# Altium schematic sheet G-USB.SchDoc(G-USB)
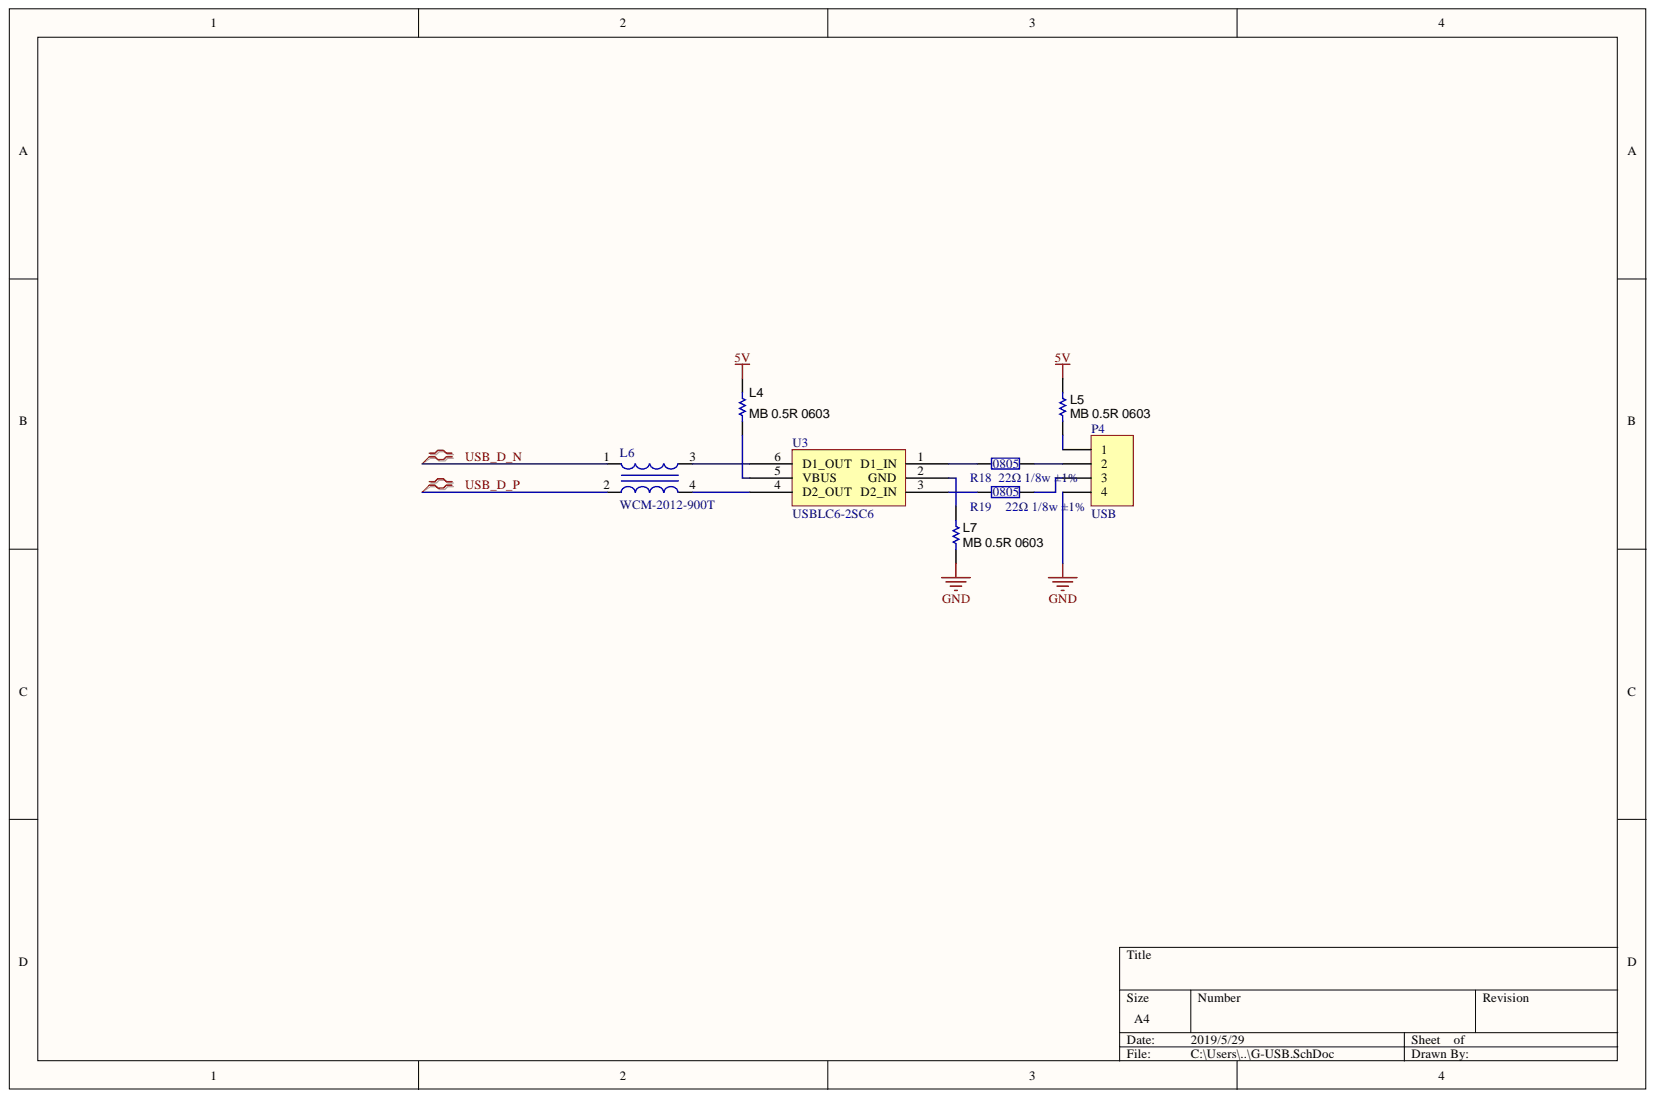
[diagram p0: G-USB.SchDoc]

## Parts
L4  MB 0.5R 0603  [R-0603]
L5  MB 0.5R 0603  [R-0603]
L6  WCM-2012-900T  [贴片共模电感-2520]
L7  MB 0.5R 0603  [R-0603]
P4  USB  [USB-B1]
R18  22Ω 1/8w ±1%  [R-0805]
R19  22Ω 1/8w ±1%  [R-0805]
U3  USBLC6-2SC6  [USBLC6]

## Nets
5V: L4.1 L5.1
GND: L7.2 P4.4
USB_D_N: L6.1
USB_D_P: L6.2
NetL4_2: L4.2 U3.5(VBUS)
NetL5_2: L5.2 P4.1
NetL6_3: L6.3 U3.6(D1_OUT)
NetL6_4: L6.4 U3.4(D2_OUT)
NetL7_1: L7.1 U3.2(GND)
NetP4_2: P4.2 R18.2
NetP4_3: P4.3 R19.2
NetR18_1: R18.1 U3.1(D1_IN)
NetR19_1: R19.1 U3.3(D2_IN)

## Library data of the parts
L4 L5 L7 = RC0603FR-074K99L: magnetic bead; Manufacturer: Yageo America; CompType: Resistor; EIA_Code: E96; Power_W: 0.1; Res_ohm: 4990; TempCo: 200 ppm/C; Tolerance_%: 1; U/M: EA; Value: 4.99k; Value1: 1%; Value2: 0.1W; Value_Code: 4k99; VDC_V: 150; Component Kind: Standard; ComponentLink1Description: Datasheet link; ComponentLink1URL: http://www.yageo.com/pdf/Pu-RC0603_51_PbFree_L_2.pdf; LatestRevisionDate: 2014-12-16; Library Name: Resistor_0603.IntLib; MountType: SMT; PackageReference: 0603; PartNumber: RC0603FR-074K99L; Published: 2008-06-26; RoHS: Y; Signal Integrity: Resistor; Simulation: Resistor; Supplier 1: Digi-Key; Supplier 2: Mouser; Supplier 3: Farnell; Supplier Part Number 1: 311-4.99KHRCT-ND; Supplier Part Number 2: 603-RC0603FR-074K99L; Supplier Part Number 3: 1799345; SymbolVersion: 2009-05-29; Technology: Thick Film; Publisher: Texas Instruments
L6 = INDUCTOR C: Component Kind: Standard; Library Name: DH-SchLib.SchLib
P4 = Header 4: Header, 4-Pin; Component Kind: Standard; LatestRevisionDate: 17-Jul-2002; LatestRevisionNote: Re-released for DXP Platform.; Library Name: Miscellaneous Connectors.IntLib; Signal Integrity: Connector; Publisher: Altium Limited
R18 R19 = 3kΩ 1/10w ±1%: Component Kind: Standard; Library Name: dahuan.SchLib
U3 = USBLC6-2: Component Kind: Standard; Library Name: DH-SchLib.SchLib
